# Altium schematic sheet rpmsens.SchDoc
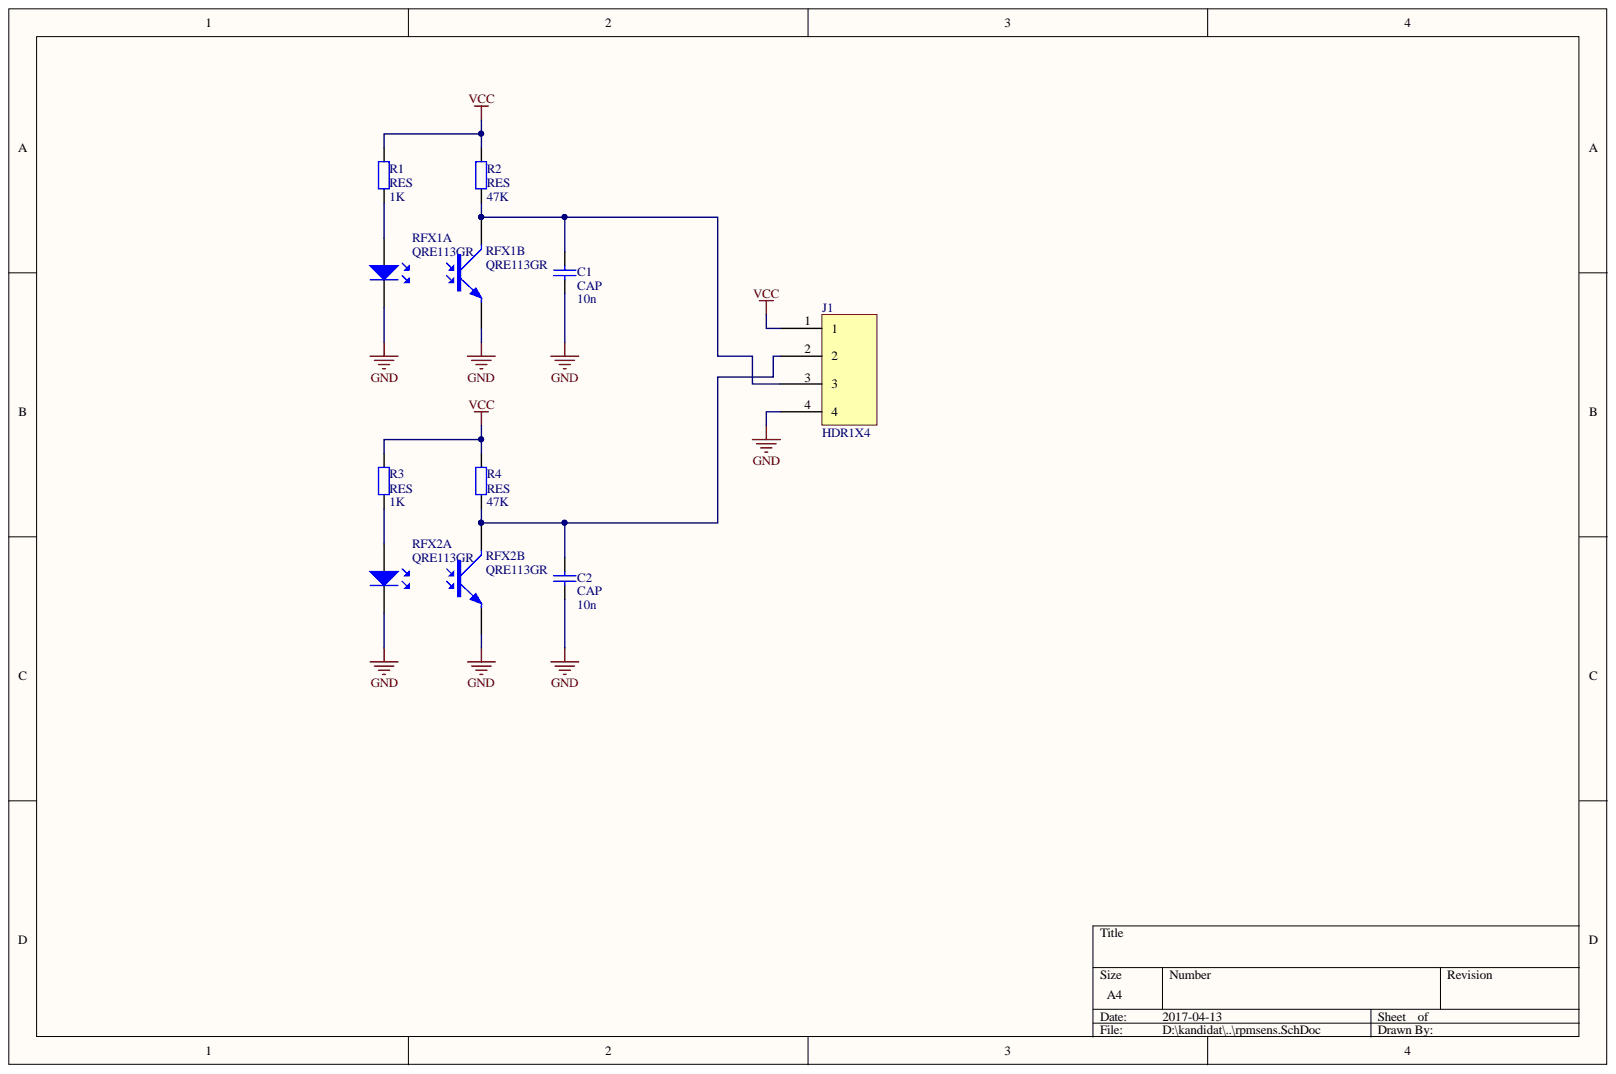
[diagram p0: rpmsens.SchDoc]

## Parts
C1  CAP  value 10n  [CAPC1608X08M]
C2  CAP  value 10n  [CAPC1608X08M]
J1  HDR1X4  [HEADER_1X4]
R1  RES  value 1K  [RESC1608X06M]
R2  RES  value 47K  [RESC1608X06M]
R3  RES  value 1K  [RESC1608X06M]
R4  RES  value 47K  [RESC1608X06M]
RFX1  QRE113GR  [RFX4]  (parts A,B)
RFX2  QRE113GR  [RFX4]  (parts A,B)

## Nets
GND: C1.1 C2.1 J1.4 RFX1.2 RFX1.4 RFX2.2 RFX2.4
VCC: J1.1 R1.2 R2.2 R3.2 R4.2
NetC1_2: C1.2 J1.3 R2.1 RFX1.3
NetC2_2: C2.2 J1.2 R4.1 RFX2.3
NetR1_1: R1.1 RFX1.1
NetR3_1: R3.1 RFX2.1

## Library data of the parts
C1 C2 = CAP: Capacitor; Component Kind: Standard; LatestRevisionDate: 17-Jul-2002; LatestRevisionNote: Re-released for DXP Platform.; Library Name: std.SchLib; PackageDescription: Polarized Capacitor (Radial); 2 Leads; PackageReference: RB7.6-15; Published: 8-Jun-2000; Publisher: Altium Limited
J1 = HDR1X4: 4pin header; Component Kind: Standard; Library Name: std.SchLib
R1-R4 = RES: Resistor; Component Kind: Standard; LatestRevisionDate: 17-Jul-2002; LatestRevisionNote: Re-released for DXP Platform.; Library Name: std.SchLib; PackageDescription: Axial Device, Thru-Hole; 2 Leads; 0.4 in Pin Spacing; PackageReference: AXIAL-0.4; Published: 8-Jun-2000; Signal Integrity: Res; Simulation: RESISTOR; Publisher: Altium Limited
RFX1 RFX2 = QRE113GR: SMT Reflective Object Sensor; Component Kind: Standard; Library Name: std.SchLib
